# Altium schematic sheet video.SchDoc
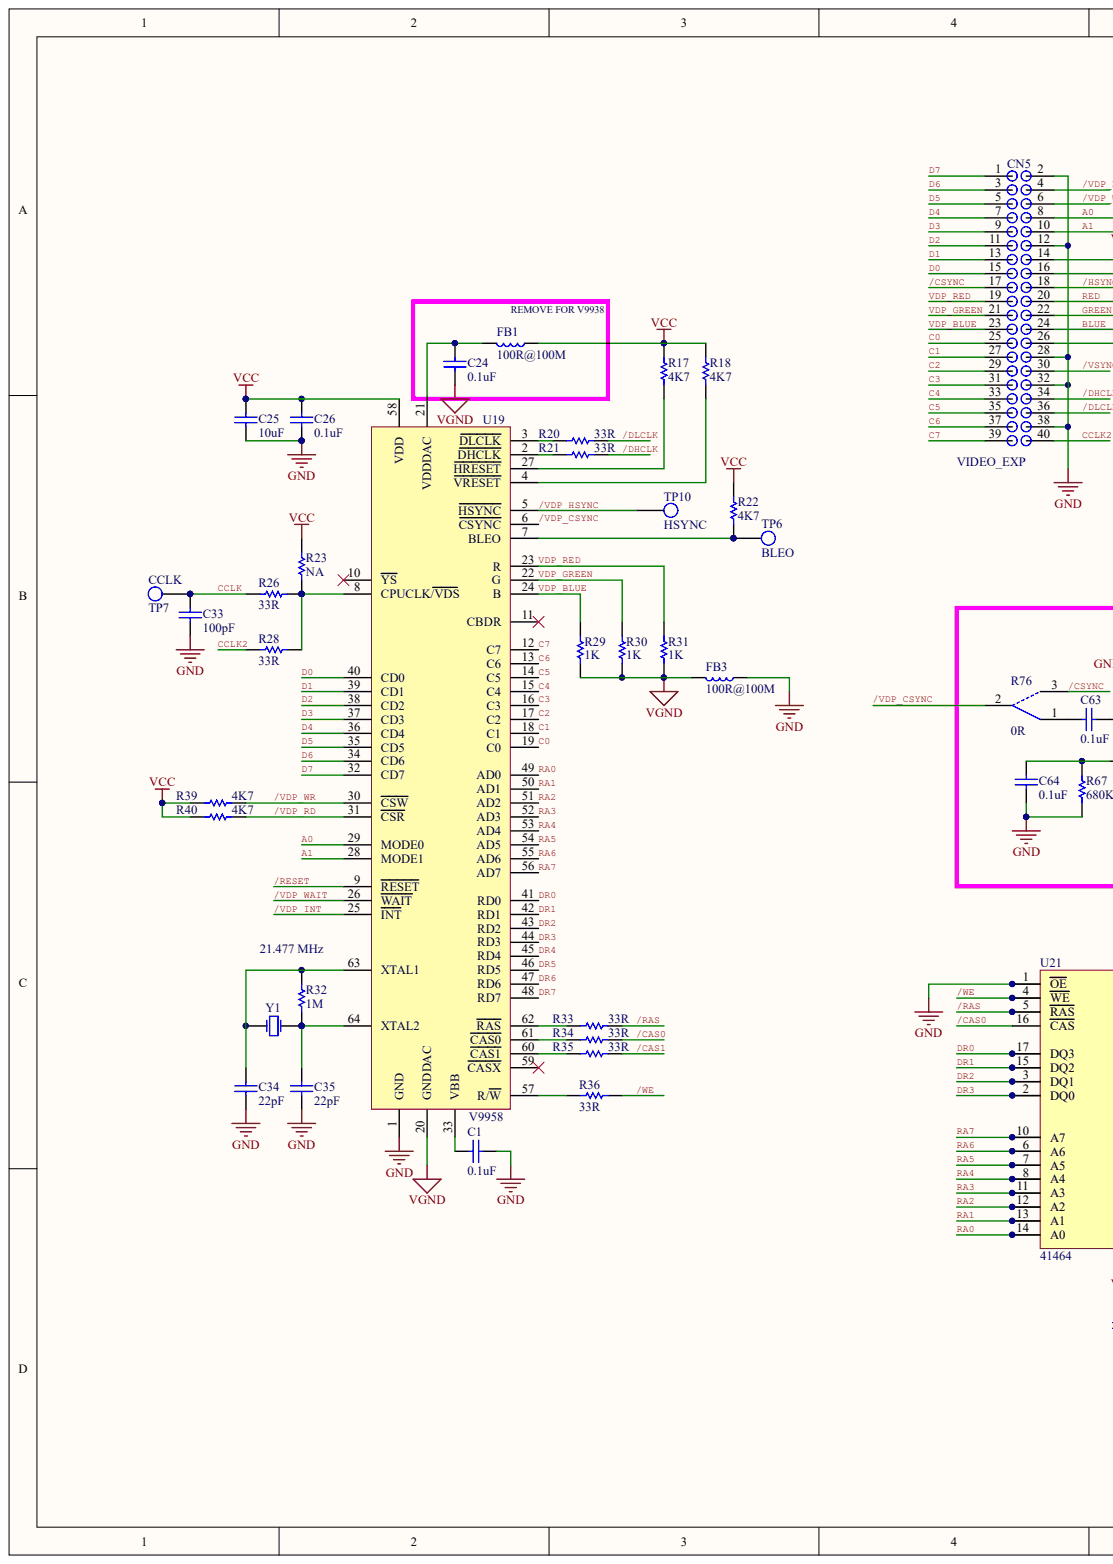
[diagram p0: video.SchDoc, left]
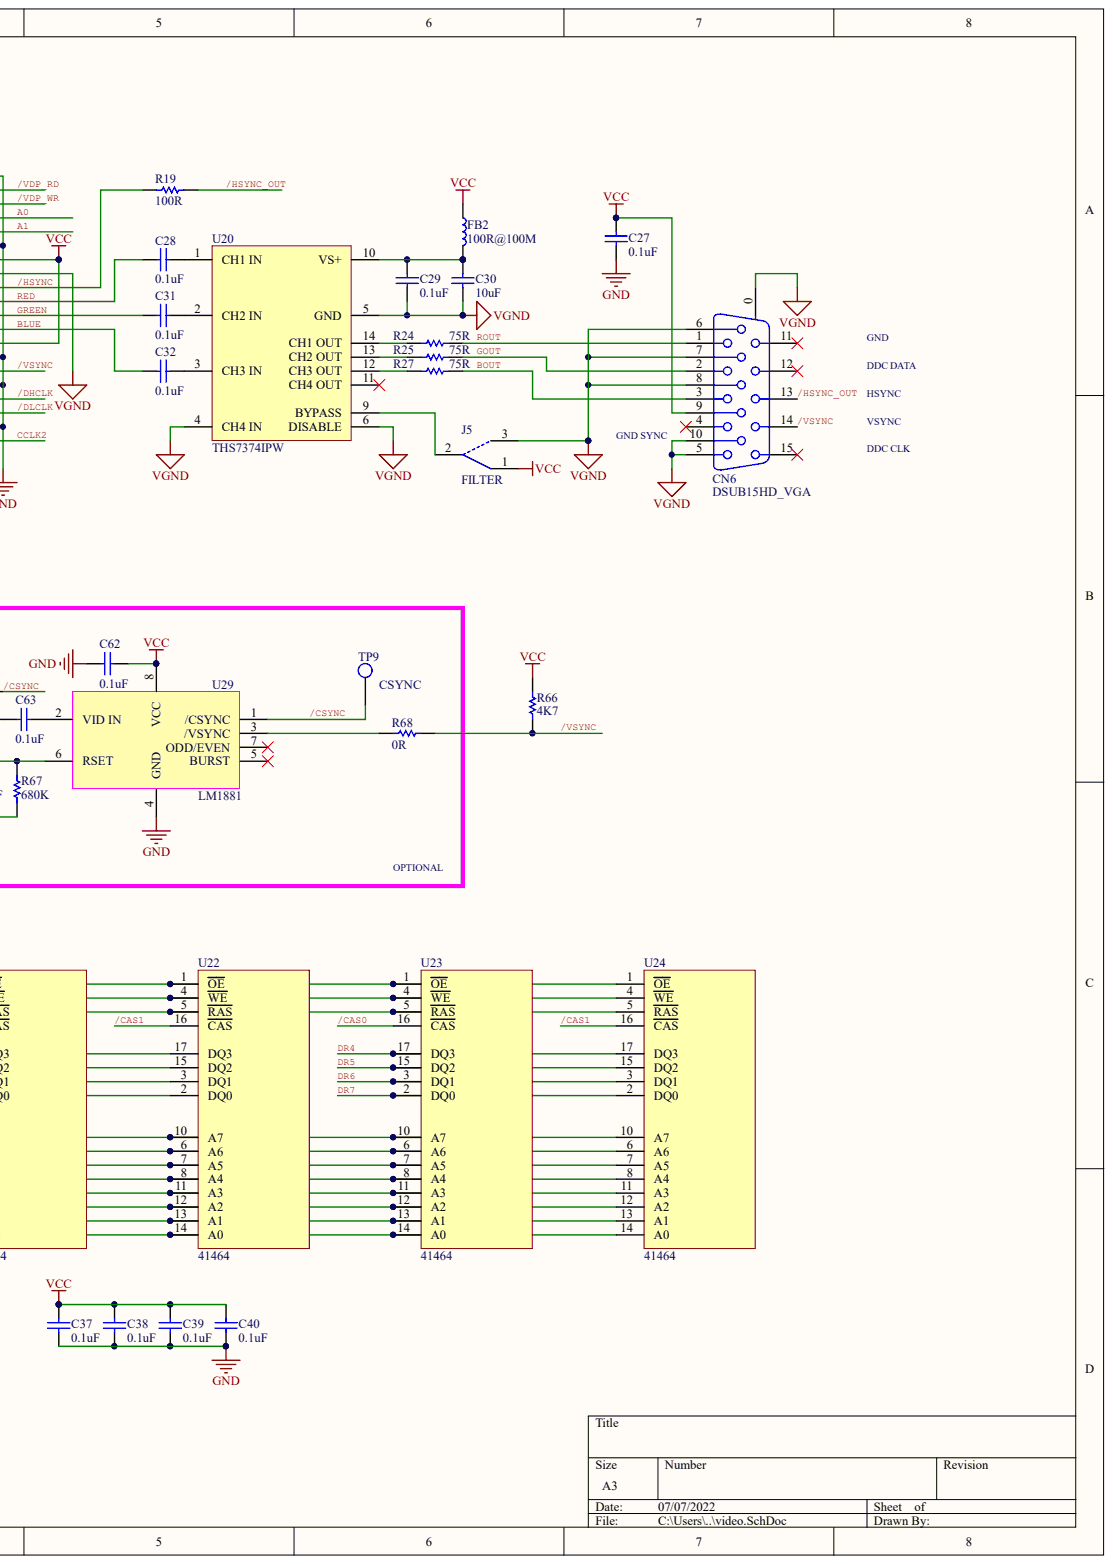
[diagram p1: video.SchDoc, right]

## Parts
C1  0.1uF
C24  0.1uF
C25  10uF
C26  0.1uF
C27  0.1uF
C28  0.1uF
C29  0.1uF
C30  10uF
C31  0.1uF
C32  0.1uF
C33  100pF
C34  22pF
C35  22pF
C37  0.1uF
C38  0.1uF
C39  0.1uF
C40  0.1uF
C62  0.1uF
C63  0.1uF
C64  0.1uF
CN5  VIDEO_EXP
CN6  DSUB15HD_VGA
FB1  100R@100M
FB2  100R@100M
FB3  100R@100M
J5  FILTER
R17  4K7
R18  4K7
R19  100R
R20  33R
R21  33R
R22  4K7
R23  NA
R24  75R
R25  75R
R26  33R
R27  75R
R28  33R
R29  1K
R30  1K
R31  1K
R32  1M
R33  33R
R34  33R
R35  33R
R36  33R
R39  4K7
R40  4K7
R66  4K7
R67  680K
R68  0R
R76  0R
TP6  BLEO
TP7  CCLK
TP9  CSYNC
TP10  HSYNC
U19  V9958
U20  THS7374IPW
U21  41464
U22  41464
U23  41464
U24  41464
U29  LM1881
Y1  21.477 MHz

## Nets
GND: C1.1 C25.1 C26.1 C27.1 C33.1 C34.1 C35.1 C37.1 C38.1 C39.1 C40.1 C62.2 C64.1 CN5.2 CN5.12 CN5.28 CN5.32 CN5.38 FB3.1 R67.2 U19.1(GND) U21.1(OE) U21.18 U22.1(OE) U22.18 U23.1(OE) U23.18 U24.1(OE) U24.18 U29.4(GND)
VCC: C25.2 C26.2 C27.2 C37.2 C38.2 C39.2 C40.2 C62.1 CN5.14 CN5.26 CN6.9 FB1.1 FB2.1 J5.1 R17.1 R18.1 R22.1 R23.1 R39.1 R40.1 R66.1 U19.58(VDD) U21.9 U22.9 U23.9 U24.9 U29.8(VCC)
VGND: C24.1 C29.1 C30.1 CN5.16 CN6.0 CN6.5 CN6.6 CN6.7 CN6.8 CN6.10 FB3.2 J5.3 R29.2 R30.2 R31.2 U19.20(GNDDAC) U20.4(CH4_IN) U20.5(GND) U20.6(DISABLE)
/CAS0: R34.1 U21.16(CAS) U23.16(CAS)
/CAS1: R35.1 U22.16(CAS) U24.16(CAS)
/CSYNC: CN5.17 R76.3 TP9.1 U29.1(/CSYNC)
/DHCLK: CN5.34 R21.1
/DLCLK: CN5.36 R20.1
/HSYNC: CN5.18 R19.1
/HSYNC_OUT: CN6.13 R19.2
/RAS: R33.1 U21.5(RAS) U22.5(RAS) U23.5(RAS) U24.5(RAS)
/RESET: U19.9(RESET)
/VDP_CSYNC: R76.2 U19.6(CSYNC)
/VDP_HSYNC: TP10.1 U19.5(HSYNC)
/VDP_INT: U19.25(INT)
/VDP_RD: CN5.4 R40.2 U19.31(CSR)
/VDP_WAIT: U19.26(WAIT)
/VDP_WR: CN5.6 R39.2 U19.30(CSW)
/VSYNC: CN5.30 CN6.14 R66.2 R68.2
/WE: R36.1 U21.4(WE) U22.4(WE) U23.4(WE) U24.4(WE)
A0: CN5.8 U19.29(MODE0)
A1: CN5.10 U19.28(MODE1)
BLUE: C32.1 CN5.24
BOUT: CN6.3 R27.2
C0: CN5.25 U19.19(C0)
C1: CN5.27 U19.18(C1)
C2: CN5.29 U19.17(C2)
C3: CN5.31 U19.16(C3)
C4: CN5.33 U19.15(C4)
C5: CN5.35 U19.14(C5)
C6: CN5.37 U19.13(C6)
C7: CN5.39 U19.12(C7)
CCLK: C33.2 R26.2 TP7.1
CCLK2: CN5.40 R28.2
D0: CN5.15 U19.40(CD0)
D1: CN5.13 U19.39(CD1)
D2: CN5.11 U19.38(CD2)
D3: CN5.9 U19.37(CD3)
D4: CN5.7 U19.36(CD4)
D5: CN5.5 U19.35(CD5)
D6: CN5.3 U19.34(CD6)
D7: CN5.1 U19.32(CD7)
DR0: U19.41(RD0) U21.17(DQ3) U22.17(DQ3)
DR1: U19.42(RD1) U21.15(DQ2) U22.15(DQ2)
DR2: U19.43(RD2) U21.3(DQ1) U22.3(DQ1)
DR3: U19.44(RD3) U21.2(DQ0) U22.2(DQ0)
DR4: U19.45(RD4) U23.17(DQ3) U24.17(DQ3)
DR5: U19.46(RD5) U23.15(DQ2) U24.15(DQ2)
DR6: U19.47(RD6) U23.3(DQ1) U24.3(DQ1)
DR7: U19.48(RD7) U23.2(DQ0) U24.2(DQ0)
GOUT: CN6.2 R25.2
GREEN: C31.1 CN5.22
RA0: U19.49(AD0) U21.14(A0) U22.14(A0) U23.14(A0) U24.14(A0)
RA1: U19.50(AD1) U21.13(A1) U22.13(A1) U23.13(A1) U24.13(A1)
RA2: U19.51(AD2) U21.12(A2) U22.12(A2) U23.12(A2) U24.12(A2)
RA3: U19.52(AD3) U21.11(A3) U22.11(A3) U23.11(A3) U24.11(A3)
RA4: U19.53(AD4) U21.8(A4) U22.8(A4) U23.8(A4) U24.8(A4)
RA5: U19.54(AD5) U21.7(A5) U22.7(A5) U23.7(A5) U24.7(A5)
RA6: U19.55(AD6) U21.6(A6) U22.6(A6) U23.6(A6) U24.6(A6)
RA7: U19.56(AD7) U21.10(A7) U22.10(A7) U23.10(A7) U24.10(A7)
RED: C28.1 CN5.20
ROUT: CN6.1 R24.2
VDP_BLUE: CN5.23 R29.1 U19.24(B)
VDP_GREEN: CN5.21 R30.1 U19.22(G)
VDP_RED: CN5.19 R31.1 U19.23(R)
NetC1_2: C1.2 U19.33(VBB)
NetC24_2: C24.2 FB1.2 U19.21(VDDDAC)
NetC28_2: C28.2 U20.1(CH1_IN)
NetC29_2: C29.2 C30.2 FB2.2 U20.10(VS+)
NetC31_2: C31.2 U20.2(CH2_IN)
NetC32_2: C32.2 U20.3(CH3_IN)
NetC34_2: C34.2 R32.1 U19.63(XTAL1) Y1.2
NetC35_2: C35.2 R32.2 U19.64(XTAL2) Y1.1
NetC63_1: C63.1 U29.2(VID_IN)
NetC63_2: C63.2 R76.1
NetC64_2: C64.2 R67.1 U29.6(RSET)
NetJ5_2: J5.2 U20.9(BYPASS)
NetR17_2: R17.2 U19.27(HRESET)
NetR18_2: R18.2 U19.4(VRESET)
NetR20_2: R20.2 U19.3(DLCLK)
NetR21_2: R21.2 U19.2(DHCLK)
NetR22_2: R22.2 TP6.1 U19.7(BLEO)
NetR23_2: R23.2 R26.1 R28.1 U19.8(CPUCLK/VDS)
NetR24_1: R24.1 U20.14(CH1_OUT)
NetR25_1: R25.1 U20.13(CH2_OUT)
NetR27_1: R27.1 U20.12(CH3_OUT)
NetR33_2: R33.2 U19.62(RAS)
NetR34_2: R34.2 U19.61(CAS0)
NetR35_2: R35.2 U19.60(CAS1)
NetR36_2: R36.2 U19.57(R/W)
NetR68_1: R68.1 U29.3(/VSYNC)

Not connected: CN6 4 11 12 15; U19 10(YS) 11(CBDR) 59(CASX); U20 11(CH4_OUT); U29 5(BURST) 7(ODD/EVEN)

## Library data of the parts
CN6 = (no symbol name): PackageDocument: B; Positions: 15; Gender: Receptacle Header; Published: 15-Jun-2001; LatestRevisionDate: 17-Jul-2002; LatestRevisionNote: Re-released for DXP Platform.; DatasheetDocument: 24-Nov-1999; PackageReference: 788574; Orientation: Right Angle; Publisher: Altium Limited
C1 C24-C35 C37-C40 C62-C64 CN5 FB1-FB3 J5 R17-R36 R39 R40 R66-R68 R76 TP6 TP7 TP9 TP10 U19-U24 U29 Y1 = (no symbol name)
  C1 C24 C26-C29 C31 C32 C37-C40 C62-C64: VALUE: 0.1uF
  C25 C30: VALUE: 10uF
  C33: VALUE: 100pF
  C34 C35: VALUE: 22pF
  FB1-FB3: VALUE: 100R@100M
  J5: VALUE: FILTER
  R17 R18 R22 R39 R40 R66: Value: 4K7
  R19: Value: 100R
  R20 R21 R26 R28 R33-R36: Value: 33R
  R23: Value: NA
  R24 R25 R27: Value: 75R
  R29-R31: Value: 1K
  R32: Value: 1M
  R67: Value: 680K
  R68: Value: 0R
  R76: VALUE: 0R
  TP6: Value: BLEO
  TP7: Value: CCLK
  TP9: Value: CSYNC
  TP10: Value: HSYNC
  U19: PartNumber: V9958
  U20: PartNumber: THS7374IPW
  U21-U24: PartNumber: 41464
  U29: PartNumber: LM1881
  Y1: VALUE: 21.477 MHz
